# Altium schematic sheet Input_PS_110V.SchDoc
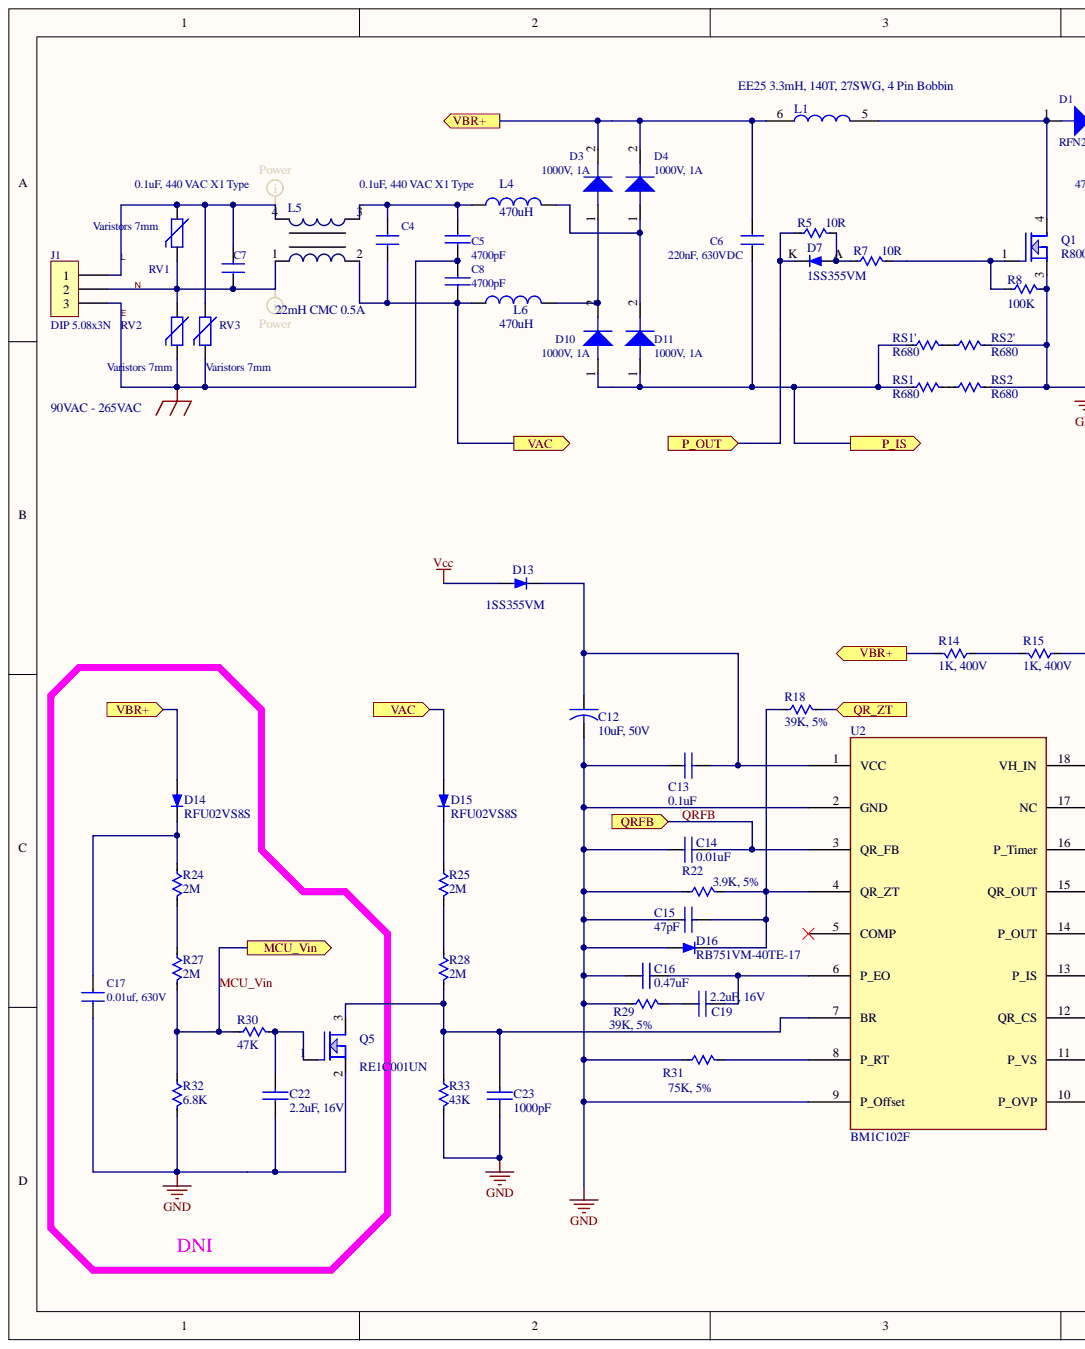
[diagram p0: Input_PS_110V.SchDoc, left]
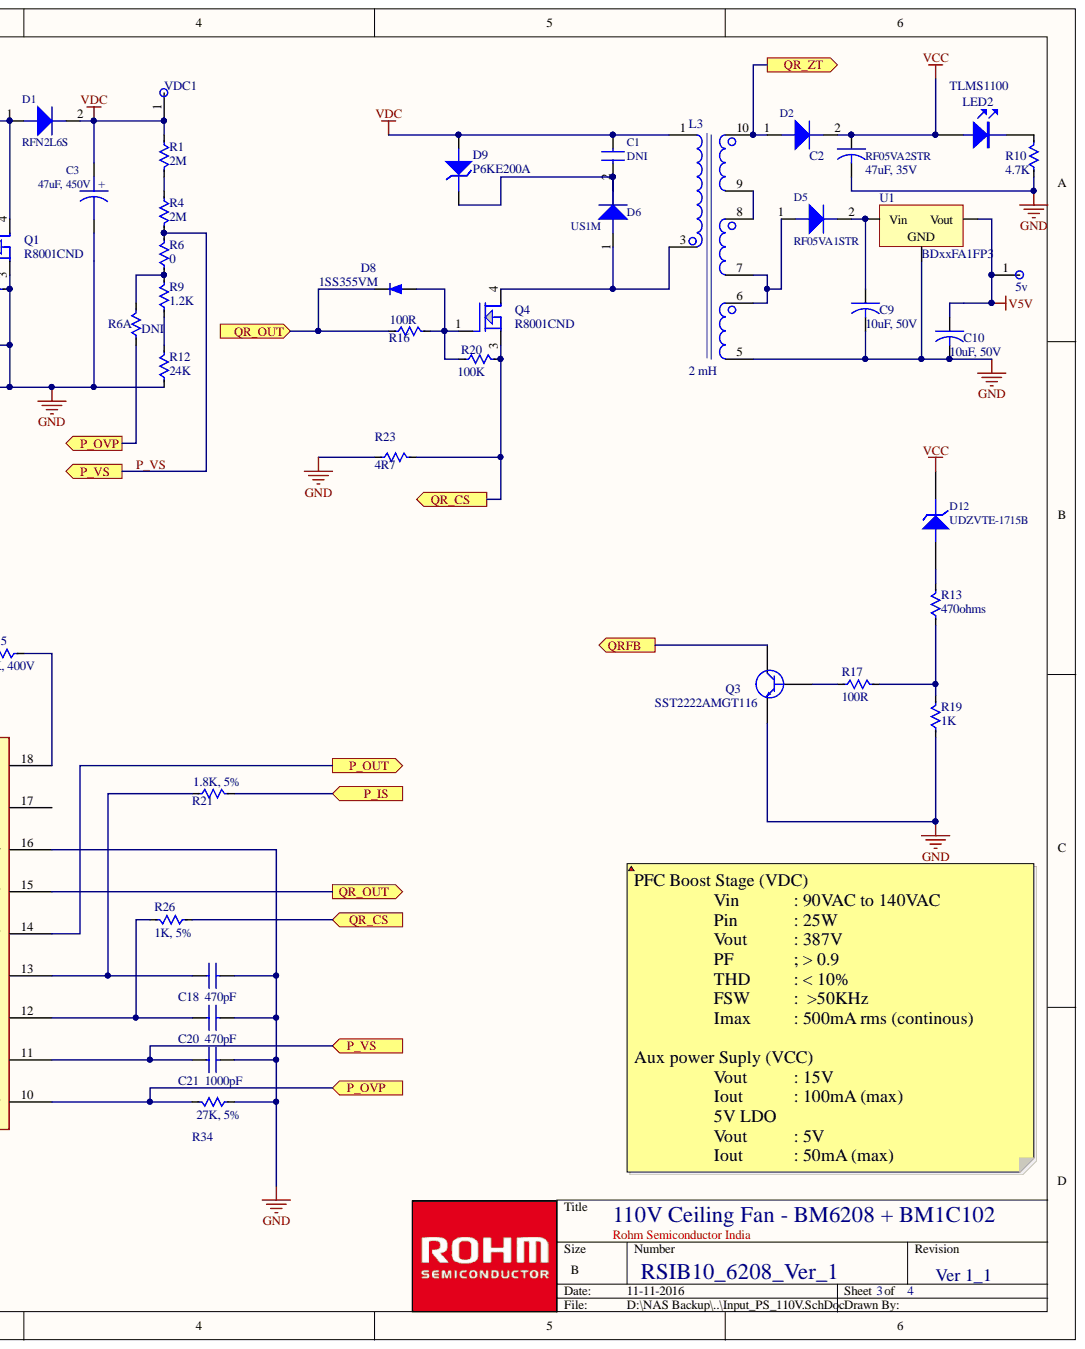
[diagram p1: Input_PS_110V.SchDoc, right]

## Parts
5v  TP  [TP_5002]  (value not drawn)
C1  DNI  [Cap_DISC]
C2  47uF, 35V  [CAP_M_5/2]
C3  47uF, 450V  Voltage: 450V  [Cap_elec_30x17.5_7.5mm]
C4  0.1uF, 440 VAC X1 Type  Voltage: 440 VAC  [CAP_17.5X6.5X15]
C5  4700pF  [CAP_12X4X7.5]
C6  220nF, 630VDC  Voltage: 630VDC  [Cap - 15.24mm BOX]
C7  0.1uF, 440 VAC X1 Type  [CAP_17.5X6.5X15]
C8  4700pF  [CAP_12X4X7.5]
C9  10uF, 50V  Voltage: 50V  [CAP_M_5/2]
C10  10uF, 50V  Voltage: 50V  [CAP_M_5/2]
C12  10uF, 50V  Voltage: 50V  [CAP_M_5/2]
C13  0.1uF  [C0805]
C14  0.01uF  [C0805]
C15  47pF  [C0805]
C16  0.47uF  [C0805]
C17  0.01uf, 630V  [Cap_DISC-KV_REV1]
C18  470pF  [c0603]
C19  2.2uF, 16V  [C0805]
C20  470pF  [c0603]
C21  1000pF  [C0805]
C22  2.2uF, 16V  [C0805]
C23  1000pF  [C0805]
D1  RFN2L6S  [SMA/1_2]
D2  RF05VA2STR  [SMA/1_2]
D3  1000V, 1A  [SMA/1_2]
D4  1000V, 1A  [SMA/1_2]
D5  RF05VA1STR  [SMA/1_2]
D6  US1M  [SMA/1_2]
D7  1SS355VM  [SOD323]
D8  1SS355VM  [SOD323]
D9  P6KE200A  [AXIAL 0.2_V]
D10  1000V, 1A  [SMA/1_2]
D11  1000V, 1A  [SMA/1_2]
D12  UDZVTE-1715B  [1005L]
D13  1SS355VM  [SOD323]
D14  RFU02VS8S  [SOD323]
D15  RFU02VS8S  [1005L]
D16  RB751VM-40TE-17  [SOD323]
J1  DIP 5.08x3N  [CON_10mm pitch]
L1  EE25 3.3mH, 140T, 27SWG, 4 Pin Bobbin  [EE2507_10Pin_H]
L3  2 mH  [EE1306_10Pin_V]
L4  470uH  [L_9/5.0_H1.2mm]
L5  22mH CMC 0.5A  [L1310S]
L6  470uH  [L_9/5.0_H1.2mm]
LED2  TLMS1100  [TLMS1100]
Q1  R8001CND  [DPAK]
Q3  SST2222AMGT116  [SOT-23]
Q4  R8001CND  [DPAK]
Q5  RE1C001UN  [SOT-23B]
R1  2M  [R1206]
R4  2M  [R1206]
R5  10R  [R0805]
R6  0  [R0805]
R6A  DNI  [R0805]
R7  10R  [R0805]
R8  100K  [R0805]
R9  1.2K  [R0805]
R10  4.7K  [R0805]
R12  24K  [R0805]
R13  470ohms  [R0805]
R14  1K, 400V  [R0805]
R15  1K, 400V  [R0805]
R16  100R  [R0805]
R17  100R  [R0805]
R18  39K, 5%  [R0805]
R19  1K  [R0805]
R20  100K  [R0805]
R21  1.8K, 5%  [R0805]
R22  3.9K, 5%  [R0805]
R23  4R7  [R1210]
R24  2M  [R1206]
R25  2M  [R1206]
R26  1K, 5%  [R0805]
R27  2M  [R1206]
R28  2M  [R1206]
R29  39K, 5%  [R0805]
R30  47K  [R0805]
R31  75K, 5%  [R0805]
R32  6.8K  [R0805]
R33  43K  [R0805]
R34  27K, 5%  [R0805]
RS1  R680  [R2512]
RS1'  R680  [R2512]
RS2  R680  [R2512]
RS2'  R680  [R2512]
RV1  Varistors 7mm  [5D-9]
RV2  Varistors 7mm  [5D-9]
RV3  Varistors 7mm  [5D-9]
U1  BDxxFA1FP3  [SOT-893K]
U2  BM1C102F  [BM1C102 - SOP127P780X190-18N]
VDC1  TP  [TP_5002]  (value not drawn)

## Ports
MCU_VIN, P_IS, P_OUT, P_OVP, P_VS, QR_CS, QR_OUT, QR_ZT, QRFB, VAC, VBR+

## Nets
GND: C2.2 C3.2 C9.2 C10.2 C12.2 C13.1 C14.1 C15.1 C16.1 C17.2 C18.2 C20.2 C21.2 C22.1 C23.1 D16.A L3.5 Q1.3 Q3.2 Q5.2 R8.2 R10.1 R12.1 R19.1 R22.1 R23.1 R29.1 R31.1 R32.2 R33.2 R34.1 RS2.2 RS2'.2 U1.2 U2.2(GND) U2.9(P_Offset) U2.16(P_Timer)
V5V: 5v.1 C10.1 U1.1
VCC: C2.1 D2.2 D12.K D13.A LED2.A
VDC: C1.1 C3.1 D1.2 D9.1 L3.1 R1.1 VDC1.1
E: C5.2 C8.1 J1.3 RV2.2 RV3.2
L: C7.1 J1.1 L5.4 RV1.1 RV2.1
MCU_VIN: R27.2 R30.1 R32.1 ; port MCU_VIN
N: C7.2 J1.2 L5.1 RV1.2 RV3.1
P_IS: C6.2 D10.1 D11.1 R21.2 RS1.1 RS1'.1 ; port P_IS
P_OUT: D7.K R5.1 U2.14(P_OUT) ; port P_OUT
P_OVP: R6A.1 R34.2 U2.10(P_OVP) ; port P_OVP
P_VS: C21.1 R4.2 R6.2 U2.11(P_VS) ; port P_VS
QR_CS: Q4.3 R20.2 R23.2 R26.1 ; port QR_CS
QR_OUT: D8.K R16.1 U2.15(QR_OUT) ; port QR_OUT
QR_ZT: D2.1 L3.10 R18.2 ; port QR_ZT
QRFB: C14.2 Q3.3 U2.3(QR_FB) ; port QRFB
VAC: C4.2 C8.2 D15.A L5.2 L6.1 ; port VAC
VBR+: C6.1 D3.2 D4.2 D14.A L1.6 R14.2 ; port VBR+
NetC1_2: C1.2 D6.2 D9.2
NetC4_1: C4.1 C5.1 L4.1 L5.3
NetC9_1: C9.1 D5.2 U1.3
NetC12_1: C12.1 C13.2 D13.K U2.1(VCC)
NetC15_2: C15.2 D16.K R18.1 R22.2 U2.4(QR_ZT)
NetC16_2: C16.2 C19.2 U2.6(P_EO)
NetC17_1: C17.1 D14.K R24.1
NetC18_1: C18.1 R21.1 U2.13(P_IS)
NetC19_1: C19.1 R29.2
NetC20_1: C20.1 R26.2 U2.12(QR_CS)
NetC22_2: C22.2 Q5.1 R30.2
NetC23_2: C23.2 Q5.3 R28.2 R33.1 U2.7(BR)
NetD1_1: D1.1 L1.5 Q1.4
NetD3_1: D3.1 D10.2 L6.2
NetD4_1: D4.1 D11.2 L4.2
NetD5_1: D5.1 L3.6 L3.7
NetD6_1: D6.1 L3.3 Q4.4
NetD7_A: D7.A R5.2 R7.1
NetD8_A: D8.A Q4.1 R16.2 R20.1
NetD12_A: D12.A R13.2
NetD15_K: D15.K R25.1
NetL3_8: L3.8 L3.9
NetLED2_K: LED2.K R10.2
NetQ1_1: Q1.1 R7.2 R8.1
NetQ3_1: Q3.1 R17.1
NetR1_2: R1.2 R4.1
NetR6_1: R6.1 R6A.2 R9.2
NetR9_1: R9.1 R12.2
NetR13_1: R13.1 R17.2 R19.2
NetR14_1: R14.1 R15.2
NetR15_1: R15.1 U2.18(VH_IN)
NetR24_2: R24.2 R27.1
NetR25_2: R25.2 R28.1
NetR31_2: R31.2 U2.8(P_RT)
NetRS1'_2: RS1'.2 RS2'.1
NetRS1_2: RS1.2 RS2.1

Not connected: U2 5(COMP) 17(NC)

## Library data of the parts
5v = TP: Section: Inverter; Value: 0; Component Kind: Standard; Supplier 1: DNI; Supplier Part Number 1: DNI; Type: DNI; Library Name: Altium_Ara_Sch_libraries_R1.SchLib
C1 = Cap - Polyster: Capcitor Disc; Section: Aux PS; Type: Ceramic Cap; Value: .09; Voltage: 1 KV; Component Kind: Standard; Supplier 1: Kemet; Supplier Part Number 1: C350C102JDG5TA7303; Library Name: Altium_Ara_Sch_libraries_R1.SchLib
C2 C9 C10 C12 = Cap TH 5/2: CAP ALUM 10UF 20% 50V RADIAL; Section: Aux PS; Type: Electrolytic; Value: 0.023; Component Kind: Standard; Supplier 1: *Samwha; Library Name: Altium_Ara_Sch_libraries_R1.SchLib
  C2: Supplier Part Number 1: SD1V476M05011PC; Voltage: 35V
  C9 C10 C12: Supplier Part Number 1: SD1H106M05011PC
C3 = Cap TH 17.5/7.5: Capacitor 16x31.5, 7.5mm P 0.8mm; Section: PFC; Type: Electrolytic; Value: .4; Component Kind: Standard; Supplier 1: *Samwha; Supplier Part Number 1: RD2W226M16025BB; Library Name: Altium_Ara_Sch_libraries_R1.SchLib
C4 = Cap - Polyster: 0.1uF 330 VAC X1 Type; Section: Input; Type: TH_CAP; Value: .1; Component Kind: Standard; Supplier 1: Kemet; Supplier Part Number 1: R474I310000A1M; Library Name: Altium_Ara_Sch_libraries_R1.SchLib
C5 C8 = CAP NP: Ceramic 4700pF , 300VAC, Y2; Section: Input; Type: Ceramic Cap; Value: 0.076; Voltage: 300VAC, 3KV; Component Kind: Standard; Supplier 1: Kemet; Supplier Part Number 1: C961U472MZWDBA7317; Library Name: Altium_Ara_Sch_libraries_R1.SchLib
C6 = Cap - Polyster: Capcitor Polyster; Section: Inverter; Type: TH_Cap; Value: 0.15; Component Kind: Standard; Supplier 1: Kemet; Supplier Part Number 1: R60PI3100AAL0K*; Library Name: Altium_Ara_Sch_libraries_R1.SchLib
C7 = Cap - Polyster: 0.1uF 330 VAC X1 Type; Section: Input; Type: TH_CAP; Value: .1; Voltage: 440 VAC; Component Kind: Standard; Supplier 1: Kemet; Supplier Part Number 1: R474I310000A1M; Library Name: Altium_Ara_Sch_libraries_R1.SchLib
C13 = CAP NP: Cap 0.1uF 25V X7R 0805 5%; Section: BM1C102 CTRL; Type: SMD_Cap; Value: 0.004615; Component Kind: Standard; Supplier 1: *Wurth; Supplier Part Number 1: 885 012 207 072; Library Name: Altium_Ara_Sch_libraries_R1.SchLib
C14 = CAP NP: Section: BM1C102 CTRL; Type: SMD_Cap; Value: 0.003927; Voltage: 16V; Component Kind: Standard; Supplier 1: *Murata; Supplier Part Number 1: GRM216R71C103JA01D; Library Name: Altium_Ara_Sch_libraries_R1.SchLib
C15 C20 = CAP NP: Section: BM1C102 CTRL; Type: SMD_Cap; Value: 0.0027405; Voltage: 50V; Component Kind: Standard; Supplier 1: *Murata; Supplier Part Number 1: GRM1882C1H470JA01D; Library Name: Altium_Ara_Sch_libraries_R1.SchLib
C16 = CAP NP: CAP CER 1UF 50V X5R 0805; Section: BM1C102 CTRL; Type: SMD_Cap; Value: 0; Component Kind: Standard; Supplier 1: DNI; Supplier Part Number 1: DNI; Library Name: Altium_Ara_Sch_libraries_R1.SchLib
C17 = Cap - Polyster: Capcitor Disc; Section: Aux PS; Type: TH_Cap; Value: .028; Voltage: 1 KV; Component Kind: Standard; Supplier 1: *Samwha; Supplier Part Number 1: 01 103 K 2J 1A; Library Name: Altium_Ara_Sch_libraries_R1.SchLib
C18 = CAP NP: CAP CER 4700PF 16V X7R 0603; Section: BM1C102 CTRL; Type: SMD_Cap; Value: 0.0027405; Voltage: 50V; Component Kind: Standard; Supplier 1: *Murata; Supplier Part Number 1: GRM188R71H472KA01D; Library Name: Altium_Ara_Sch_libraries_R1.SchLib
C19 = CAP NP: Multilayer Ceramic Capacitors MLCC - SMD/SMT 1uF 16Volts 10%; Section: BM1C102 CTRL; Type: SMD_Cap; Value: 0.012789; Voltage: 50V; Component Kind: Standard; Supplier 1: *Murata; Supplier Part Number 1: GRM216C81C105KA12D; Library Name: Altium_Ara_Sch_libraries_R1.SchLib
C21 C23 = CAP NP: CAP CER 1000PF 25V X7R 0805; Section: BM1C102 CTRL; Type: SMD_Cap; Value: 0.003108; Component Kind: Standard; Supplier 1: *Murata; Supplier Part Number 1: GRM216R71E102KA01D; Voltage: 25V; Library Name: Altium_Ara_Sch_libraries_R1.SchLib
C22 = CAP NP: CAP CER 2.2UF 16V X6S 0805; Section: BM1C102 CTRL; Type: SMD_Cap; Value: 0.012789; Voltage: 50V; Component Kind: Standard; Supplier 1: *Murata; Supplier Part Number 1: GRM219C81C225KA12D; Library Name: Altium_Ara_Sch_libraries_R1.SchLib
D1 = DIODE: Section: PFC; Value: 0.05550; Component Kind: Standard; Supplier 1: *Rohm; Supplier Part Number 1: RFN2L6STE25; Type: Diode
D2 = DIODE: 200V, 0.5A, TUMD2; Section: Aux PS; Value: 0.0208; Voltage: 150V; Component Kind: Standard; Supplier 1: *Rohm; Supplier Part Number 1: RF05VA2STR; Type: Diode
D3 D4 D10 D11 = DIODE: Section: PFC; Value: 0.04; Component Kind: Standard; Supplier 1: Diode Inc; Supplier Part Number 1: SM4007; Type: Diode
D5 = DIODE: 100V, 0.5A, TUMD2; Section: Aux PS; Value: 0.02; Voltage: 150V; Component Kind: Standard; Supplier 1: *Rohm; Supplier Part Number 1: RF05VA1STR; Type: Diode
D6 = DIODE: 1000V , 1A Ultra Fast; Section: Aux PS; Value: 0.0305; Component Kind: Standard; Supplier 1: Vishay; Supplier Part Number 1: US1M; Voltage: 1000V
D7 D8 D13 = DIODE_Fast: DIODE, 80V 100MA UMD2; Section: BM1C102 CTRL; Value: 0.00720; Component Kind: Standard; Supplier 1: *Rohm; Supplier Part Number 1: 1SS355VMTE-17; Type: Diode; Library Name: Altium_Ara_Sch_libraries_R1.SchLib
D9 = ZENER_A_K: Diode Zener; Value: 1; Component Kind: Standard; Library Name: Altium_Ara_Sch_libraries_R1.SchLib
D12 = ZENER3: DIODE ZENER 15V 200mW, SOD323; Section: BM1C102 CTRL; Value: 0.00750; Component Kind: Standard; Supplier 1: *Rohm; Supplier Part Number 1: UDZVTE-1715B; Type: Diode; Library Name: Altium_Ara_Sch_libraries_R1.SchLib
D14 D15 = DIODE_Fast: DIODE; Section: BM1C102 CTRL; Value: 0.02710; Component Kind: Standard; Supplier 1: *Rohm; Supplier Part Number 1: RFU02VSM8STR; Library Name: Altium_Ara_Sch_libraries_R1.SchLib
D16 = DIODE_Fast: DIODE; Section: BM1C102 CTRL; Value: 0.00880; Component Kind: Standard; Supplier 1: *Rohm; Supplier Part Number 1: RB751VM-40TE-17; Library Name: Altium_Ara_Sch_libraries_R1.SchLib
J1 = CON3: Connector; Section: Input; Value: 0.15; Component Kind: Standard; Supplier 1: *Molex; Supplier Part Number 1: 10321031; Type: Connector; Library Name: Altium_Ara_Sch_libraries_R1.SchLib
L1 = INDUCTOR_1_6: Section: Input; Type: Magnetic; Value: .2; Component Kind: Standard; Supplier 1: Custom; Supplier Part Number 1: Custom; Library Name: Altium_Ara_Sch_libraries_R1.SchLib
L3 = TRANS_Flyback_BM1C102: Type: Magnetic; Value: 0.25; Component Kind: Standard; Supplier 1: Custom; Supplier Part Number 1: Custom; Library Name: Altium_Ara_Sch_libraries_R1.SchLib
L4 L6 = INDUCTOR: Section: Aux PS; Type: Magnetics; Value: 0.021; Component Kind: Standard; Supplier 1: Custom; Supplier Part Number 1: Custom; Library Name: Altium_Ara_Sch_libraries_R1.SchLib
L5 = WE-TFC UT, 744862120: PTH-Common Mode Power Line Choke WE-TFC, L=12 mH; Section: Input; Type: Magnetic; Value: .15; Component Kind: Standard; Supplier 1: Custom; Supplier Part Number 1: Custom; Library Name: Altium_Ara_Sch_libraries_R1.SchLib
LED2 = LED: Value: 1; Component Kind: Standard; Library Name: Altium_Ara_Sch_libraries_R1.SchLib
Q1 Q4 = MOSFET N_1: Section: Aux PS; Type: Trans/Fet; Value: 0.25830; Voltage: 800V; Component Kind: Standard; Supplier 1: *Rohm; Supplier Part Number 1: R8001CNDTL; Library Name: Altium_Ara_Sch_libraries_R1.SchLib
Q3 = NPN: NPN Transistor; Section: optional; Type: Trans/Fet; Value: 0.00940; Component Kind: Standard; Supplier 1: *Rohm; Supplier Part Number 1: SST2222AMGT116; Library Name: Altium_Ara_Sch_libraries_R1.SchLib
Q5 = MOSFET N_1: Mosfet, 20V, 100mA, 3.5ohm; Section: PFC; Type: Trans/FET; Value: 0.01020; Component Kind: Standard; Supplier 1: *Rohm; Supplier Part Number 1: RE1C001UNTCL; Library Name: Altium_Ara_Sch_libraries_R1.SchLib
R1 R4 R24 R25 R27 R28 = R0805: RES SMD 2M OHM 1% 1/4W 1206; Section: BM1C102 CTRL; Value: 0.0039; Component Kind: Standard; Supplier 1: *Rohm; Supplier Part Number 1: KTR18EZPF2004; Type: SMD_resistor; Library Name: Altium_Ara_Sch_libraries_R1.SchLib
R5 R6 R6A R7 R8 = R0805: Resistor; Section: PFC; Value: 0.00126; Component Kind: Standard; Supplier 1: *Rohm; Type: SMD_resistor; Library Name: Altium_Ara_Sch_libraries_R1.SchLib
  R5 R7: Supplier Part Number 1: MCR10EZPF10R0
  R6 R6A: Supplier Part Number 1: MCR10EZPF3901
  R8: Supplier Part Number 1: MCR10EZPF1003
R9 = R0805: RES SMD 1.2K OHM 1% 1/8W 0805; Section: PFC; Value: 0.00126; Component Kind: Standard; Supplier 1: *Rohm; Supplier Part Number 1: MCR10EZPF1201; Type: SMD_resistor; Library Name: Altium_Ara_Sch_libraries_R1.SchLib
R10 = R0805: Resistor; Section: Aux PS; Value: 0.0027; Component Kind: Standard; Supplier 1: *Rohm; Supplier Part Number 1: KTR10EZPJ223; Type: SMD_resistor; Library Name: Altium_Ara_Sch_libraries_R1.SchLib
R12 = R0805: RES SMD 24K OHM 1% 1/8W 0805; Section: PFC; Value: 0.00126; Component Kind: Standard; Supplier 1: *Rohm; Supplier Part Number 1: MCR10EZPF2402; Type: SMD_resistor; Library Name: Altium_Ara_Sch_libraries_R1.SchLib
R13 R16 R17 = R0805: Resistor; Section: Aux PS; Value: 0.00090; Component Kind: Standard; Supplier 1: *Rohm; Type: SMD_resistor; Library Name: Altium_Ara_Sch_libraries_R1.SchLib
  R13: Supplier Part Number 1: MCR10EZPJ471
  R16 R17: Supplier Part Number 1: MCR10EZPJ101
R14 R15 = R0805: RES SMD 1K OHM 5% 1/8W 0805; Section: BM1C102 CTRL; Value: 0.0027; Component Kind: Standard; Supplier 1: *Rohm; Supplier Part Number 1: KTR10EZPJ102; Type: SMD_resistor; Library Name: Altium_Ara_Sch_libraries_R1.SchLib
R18 R22 R26 R31 = R0805: Resistor; Section: BM1C102 CTRL; Value: 0.00090; Component Kind: Standard; Supplier 1: *Rohm; Type: SMD_resistor; Library Name: Altium_Ara_Sch_libraries_R1.SchLib
  R18: Supplier Part Number 1: MCR10EZPJ393
  R22: Supplier Part Number 1: MCR10EZPJ153
  R26: Supplier Part Number 1: MCR10EZPJ102
  R31: Supplier Part Number 1: MCR10EZPJ363
R19 R20 = R0805: Resistor; Section: Aux PS; Value: 0.00126; Component Kind: Standard; Supplier 1: *Rohm; Type: SMD_resistor; Library Name: Altium_Ara_Sch_libraries_R1.SchLib
  R19: Supplier Part Number 1: MCR10EZPF1001
  R20: Supplier Part Number 1: MCR10EZPF1003
R21 = R0805: RES SMD 1.8K OHM 5% 1/8W 0805; Section: Aux PS; Value: 0.00090; Component Kind: Standard; Supplier 1: *Rohm; Supplier Part Number 1: MCR10EZPJ182; Type: SMD_resistor; Library Name: Altium_Ara_Sch_libraries_R1.SchLib
R23 = R0805: Resistor; Section: Aux PS; Value: 0.01080; Component Kind: Standard; Supplier 1: *Rohm; Supplier Part Number 1: MCR18EZHFL1R50; Type: SMD_resistor; Library Name: Altium_Ara_Sch_libraries_R1.SchLib
R29 = R0805: RES SMD 22K OHM 5% 1/8W 0805; Section: BM1C102 CTRL; Value: 0; Component Kind: Standard; Supplier 1: ROHM; Supplier Part Number 1: MCR10EZPJ223; Type: SMD_resistor; Library Name: Altium_Ara_Sch_libraries_R1.SchLib
R30 R32 R33 = R0805: Resistor; Section: BM1C102 CTRL; Value: 0.00126; Component Kind: Standard; Supplier 1: *Rohm; Type: SMD_resistor; Library Name: Altium_Ara_Sch_libraries_R1.SchLib
  R30: Supplier Part Number 1: MCR10EZPF4702
  R32: Supplier Part Number 1: MCR10EZPF6801
  R33: Supplier Part Number 1: MCR10EZPF4302
R34 = R0805: RES SMD 27K OHM 5% 1/8W 0805; Section: BM1C102 CTRL; Value: 0.00090; Component Kind: Standard; Supplier 1: *Rohm; Supplier Part Number 1: MCR10EZPJ273; Type: SMD_resistor; Library Name: Altium_Ara_Sch_libraries_R1.SchLib
RS1 RS1' RS2 RS2' = R0805: Resistor; Section: PFC; Value: 0.06100; Component Kind: Standard; Supplier 1: *Rohm; Supplier Part Number 1: MCR100JZHFLR300; Type: SMD_resistor; Library Name: Altium_Ara_Sch_libraries_R1.SchLib
RV1-RV3 = VARISTOR: Varistors 7mm Disc 385VDC; Section: Input; Value: .04; Component Kind: Standard; Supplier 1: *Little Fuse; Supplier Part Number 1: V320LA7PW
U1 = Volt Reg_BDxxFA: Voltage Regulator; Code - JEDEC: SMD-220; Section: Aux PS; Value: 0.05700; Component Kind: Standard; Supplier 1: *Rohm; Supplier Part Number 1: BD50FA1FP3; Type: IC; Library Name: Altium_Ara_Sch_libraries_R1.SchLib
U2 = BM1C102F: Section: BM1C102 CTRL; Value: 0.33220; Component Kind: Standard; Supplier 1: *Rohm; Supplier Part Number 1: BM1C102F-GE2; Type: IC; Library Name: Altium_Ara_Sch_libraries_R1.SchLib
VDC1 = TP: Section: PFC; Value: 0; Component Kind: Standard; Supplier 1: DNI; Supplier Part Number 1: DNI; Type: DNI; Library Name: Altium_Ara_Sch_libraries_R1.SchLib
